# Altium schematic sheet MCU.SchDoc
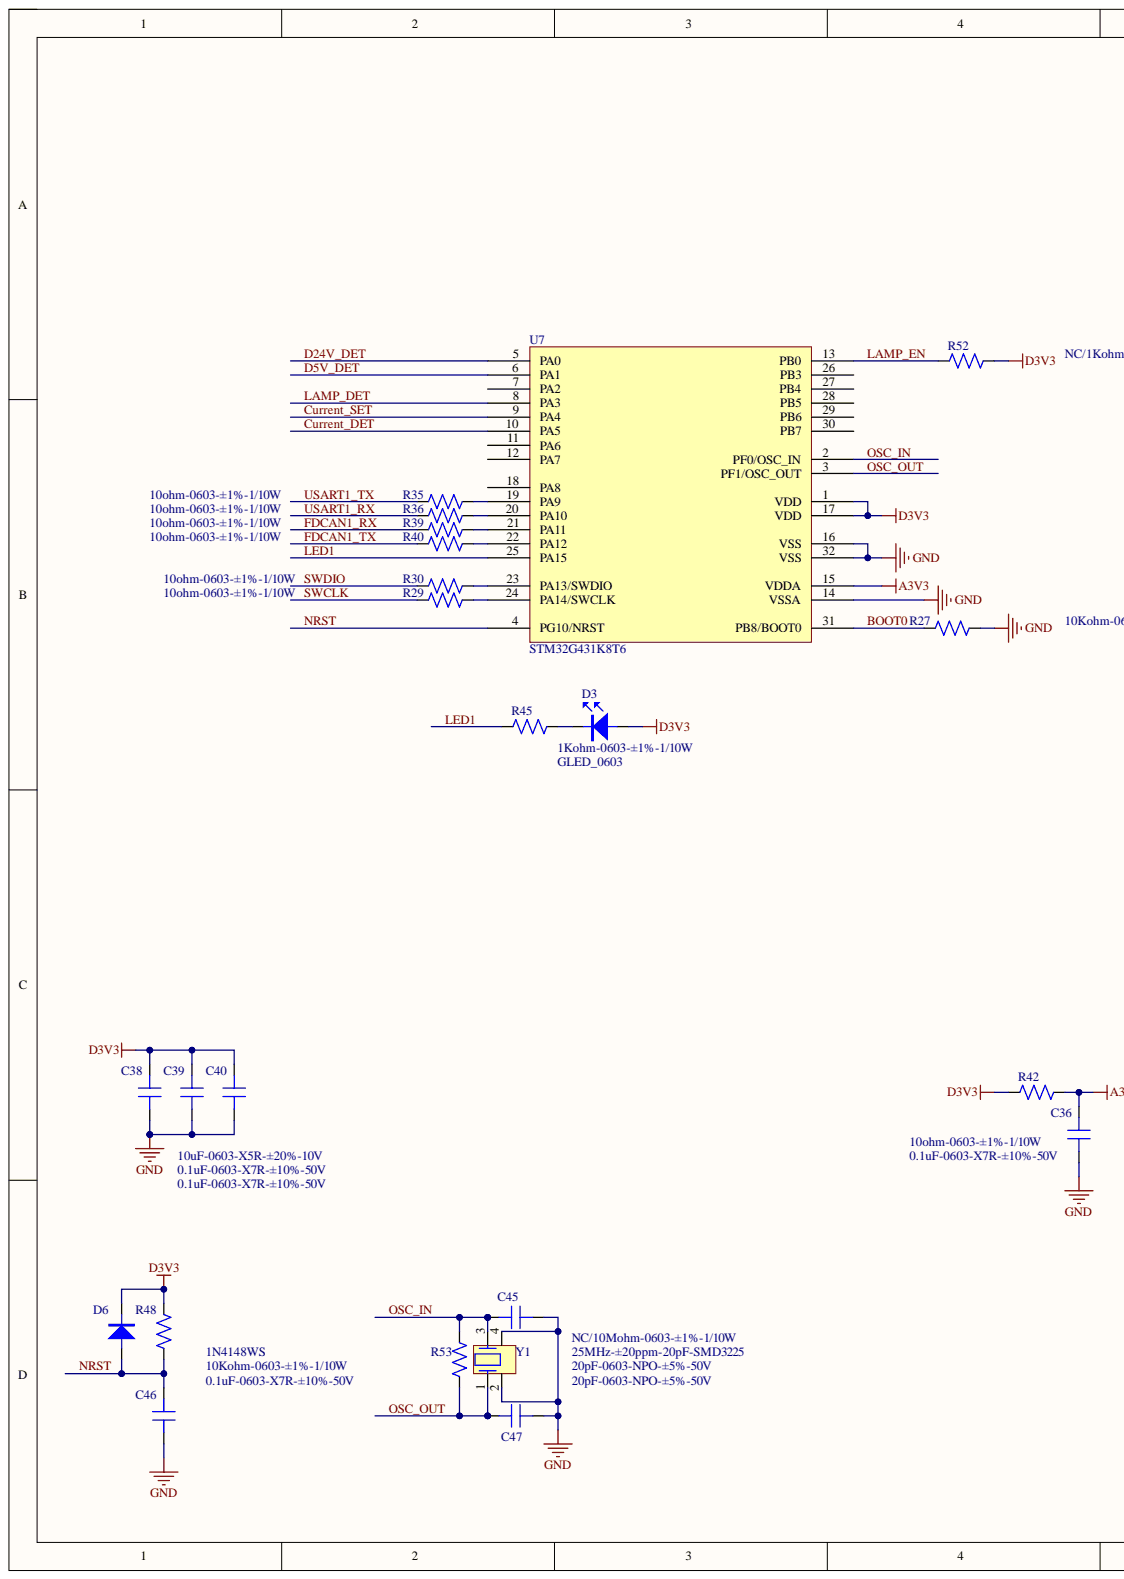
[diagram p0: MCU.SchDoc, left]
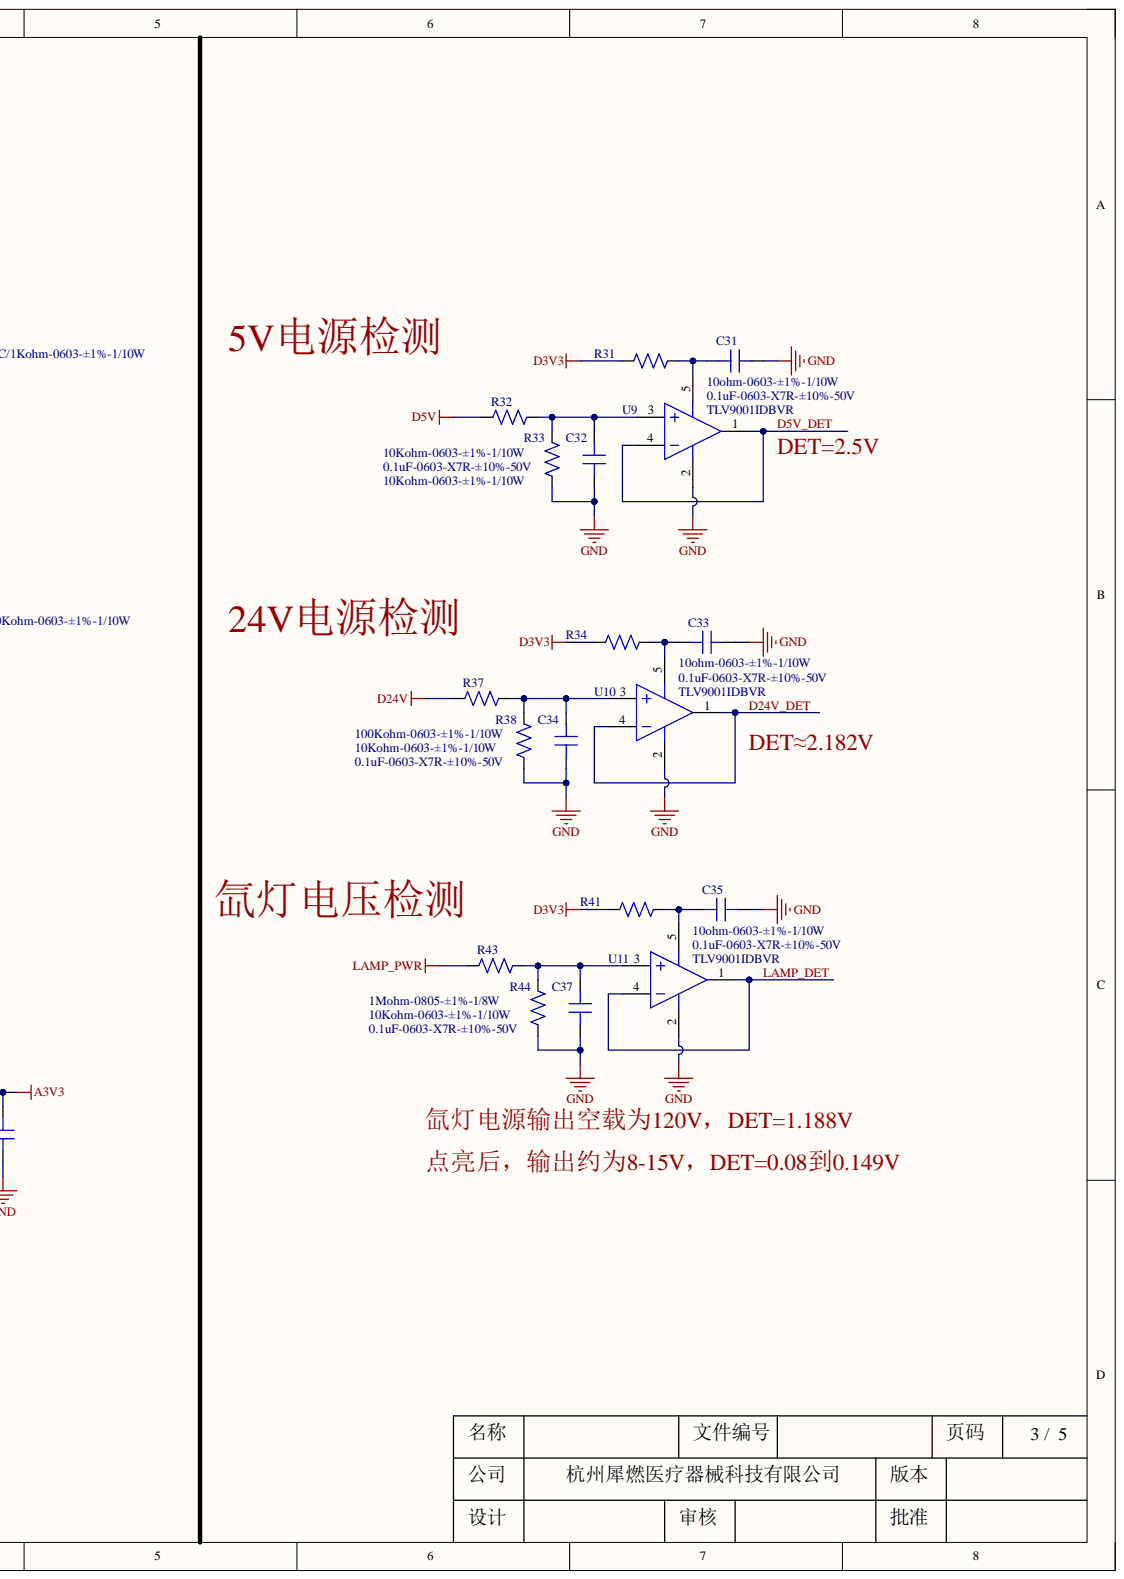
[diagram p1: MCU.SchDoc, right]

## Parts
C31  0.1uF-0603-X7R-±10%-50V  [C0603]
C32  0.1uF-0603-X7R-±10%-50V  [C0603]
C33  0.1uF-0603-X7R-±10%-50V  [C0603]
C34  0.1uF-0603-X7R-±10%-50V  [C0603]
C35  0.1uF-0603-X7R-±10%-50V  [C0603]
C36  0.1uF-0603-X7R-±10%-50V  [C0603]
C37  0.1uF-0603-X7R-±10%-50V  [C0603]
C38  10uF-0603-X5R-±20%-10V  [C0603]
C39  0.1uF-0603-X7R-±10%-50V  [C0603]
C40  0.1uF-0603-X7R-±10%-50V  [C0603]
C45  20pF-0603-NPO-±5%-50V  [C0603]
C46  0.1uF-0603-X7R-±10%-50V  [C0603]
C47  20pF-0603-NPO-±5%-50V  [C0603]
D3  GLED_0603  [LED-S0603]
D6  1N4148WS  [DIODE-S-12]
R27  10Kohm-0603-±1%-1/10W  [R0603]
R29  10ohm-0603-±1%-1/10W  [R0603]
R30  10ohm-0603-±1%-1/10W  [R0603]
R31  10ohm-0603-±1%-1/10W  [R0603]
R32  10Kohm-0603-±1%-1/10W  [R0603]
R33  10Kohm-0603-±1%-1/10W  [R0603]
R34  10ohm-0603-±1%-1/10W  [R0603]
R35  10ohm-0603-±1%-1/10W  [R0603]
R36  10ohm-0603-±1%-1/10W  [R0603]
R37  100Kohm-0603-±1%-1/10W  [R0603]
R38  10Kohm-0603-±1%-1/10W  [R0603]
R39  10ohm-0603-±1%-1/10W  [R0603]
R40  10ohm-0603-±1%-1/10W  [R0603]
R41  10ohm-0603-±1%-1/10W  [R0603]
R42  10ohm-0603-±1%-1/10W  [R0603]
R43  1Mohm-0805-±1%-1/8W  [R0805]
R44  10Kohm-0603-±1%-1/10W  [R0603]
R45  1Kohm-0603-±1%-1/10W  [R0603]
R48  10Kohm-0603-±1%-1/10W  [R0603]
R52  NC/1Kohm-0603-±1%-1/10W  [R0603]
R53  NC/10Mohm-0603-±1%-1/10W  [R0603]
U7  STM32G431K8T6  [LQFP32/0.8]
U9  TLV9001IDBVR  [SOT-23-5]
U10  TLV9001IDBVR  [SOT-23-5]
U11  TLV9001IDBVR  [SOT-23-5]
Y1  25MHz-±20ppm-20pF-SMD3225  [XTAL-S-3]

## Nets
A3V3: C36.2 R42.2 U7.15(VDDA)
D3V3: C38.2 C39.2 C40.2 D3.A D6.K R31.1 R34.1 R41.1 R42.1 R48.2 R52.2 U7.1(VDD) U7.17(VDD)
D5V: R32.1
D24V: R37.1
GND: C31.2 C32.1 C33.2 C34.1 C35.2 C36.1 C37.1 C38.1 C39.1 C40.1 C45.1 C46.1 C47.2 R27.1 R33.1 R38.1 R44.1 U7.14(VSSA) U7.16(VSS) U7.32(VSS) U9.2 U10.2 U11.2 Y1.2 Y1.4
LAMP_PWR: R43.1
BOOT0: R27.2 U7.31(PB8/BOOT0)
Current_DET: U7.10(PA5)
Current_SET: U7.9(PA4)
D5V_DET: U7.6(PA1) U9.1 U9.4
D24V_DET: U7.5(PA0) U10.1 U10.4
FDCAN1_RX: R39.1
FDCAN1_TX: R40.1
LAMP_DET: U7.8(PA3) U11.1 U11.4
LAMP_EN: R52.1 U7.13(PB0)
LED1: R45.1 U7.25(PA15)
NRST: C46.2 D6.A R48.1 U7.4(PG10/NRST)
OSC_IN: C45.2 R53.2 U7.2(PF0/OSC_IN) Y1.3
OSC_OUT: C47.1 R53.1 U7.3(PF1/OSC_OUT) Y1.1
SWCLK: R29.1
SWDIO: R30.1
USART1_RX: R36.1
USART1_TX: R35.1
NetC31_1: C31.1 R31.2 U9.5
NetC32_2: C32.2 R32.2 R33.2 U9.3
NetC33_1: C33.1 R34.2 U10.5
NetC34_2: C34.2 R37.2 R38.2 U10.3
NetC35_1: C35.1 R41.2 U11.5
NetC37_2: C37.2 R43.2 R44.2 U11.3
NetD3_K: D3.K R45.2
NetR29_2: R29.2 U7.24(PA14/SWCLK)
NetR30_2: R30.2 U7.23(PA13/SWDIO)
NetR35_2: R35.2 U7.19(PA9)
NetR36_2: R36.2 U7.20(PA10)
NetR39_2: R39.2 U7.21(PA11)
NetR40_2: R40.2 U7.22(PA12)

Not connected: U7 7(PA2) 11(PA6) 12(PA7) 18(PA8) 26(PB3) 27(PB4) 28(PB5) 29(PB6) 30(PB7)

## Library data of the parts
C31-C37 C39 C40 C46 = 0.1uF-0603-X7R-±10%-50V-D: CAP; Component Kind: Standard; Library Name: RCL.SchLib
C38 = 10uF-0603-X5R-±20%-10V-D: Component Kind: Standard; Library Name: RCL.SchLib
C45 C47 = 20pF-0603-NPO-±5%-50V-D: Component Kind: Standard; Library Name: RCL.SchLib
D6 = 1N4148WS-D: Component Kind: Standard; Library Name: Semiconductor.SchLib
R27 R32 R33 R38 R44 R48 = 10Kohm-0603-±1%-1/10W-D: Component Kind: Standard; Library Name: RCL.SchLib
R29-R31 R34-R36 R39-R42 = 10ohm-0603-±1%-1/10W-D: Component Kind: Standard; Library Name: RCL.SchLib
R37 = 100Kohm-0603-±1%-1/10W-D: Component Kind: Standard; Library Name: RCL.SchLib
R43 = 1Mohm-0805-±1%-1/8W-D: RES; Component Kind: Standard; Library Name: RCL.SchLib
R45 R52 = 1Kohm-0603-±1%-1/10W-D: Component Kind: Standard; Library Name: RCL.SchLib
R53 = 10Mohm-0603-±1%-1/10W-D: Component Kind: Standard; Library Name: RCL.SchLib
U7 = STM32G431K8T6-D: Component Kind: Standard; Library Name: Semiconductor.SchLib
U9-U11 = TLV9001IDBVR-D: Component Kind: Standard; Library Name: Semiconductor.SchLib
D3 = GLED_0603: Component Kind: Standard; Library Name: check.SchLib
Y1 = 25MHz-±20ppm-20pF-SMD3225: Component Kind: Standard; Library Name: check.SchLib
